# Altium schematic sheet RealTime.SchDoc(RealTime)
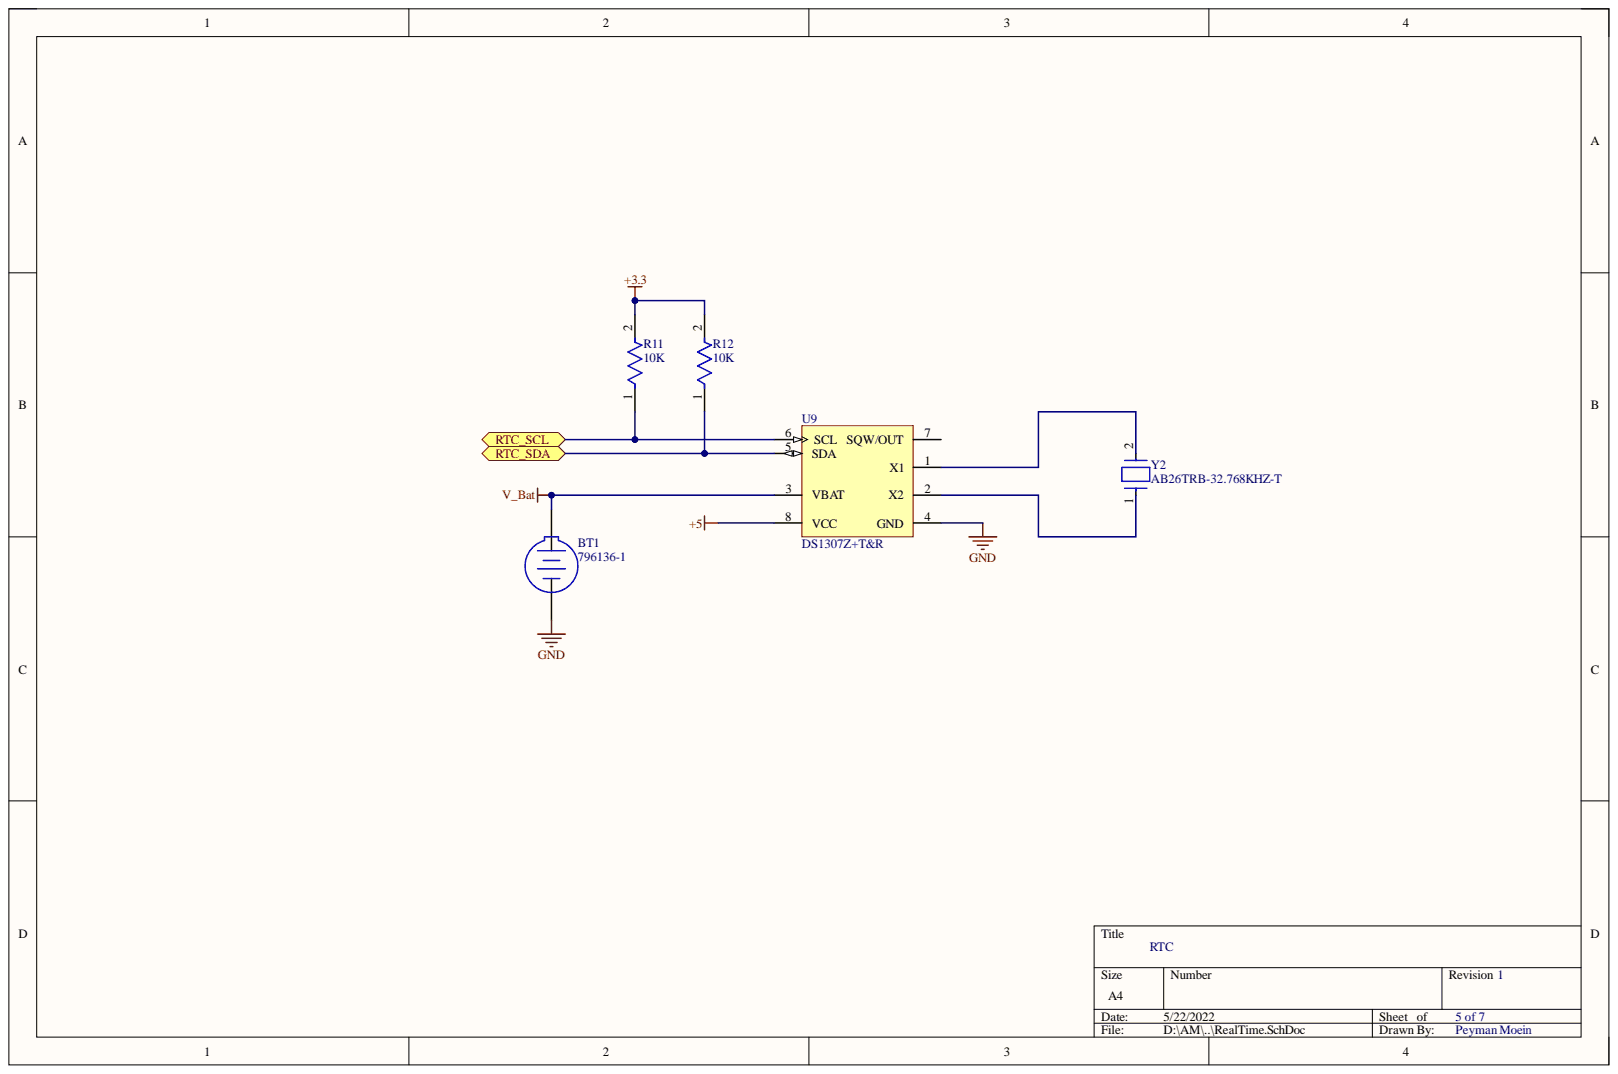
[diagram p0: RealTime.SchDoc]

## Parts
BT1  796136-1  [TECO-796136-1_V]
R11  10K  [FP-CRCW0805-e3-MFG]
R12  10K  [FP-CRCW0805-e3-MFG]
U9  DS1307Z+T&R  [S8+2_L]
Y2  AB26TRB-32.768KHZ-T  [FP-AB26TRB-MFG]

## Ports
RTC_SCL, RTC_SDA

## Nets
+3.3: R11.2 R12.2
+5: U9.8(VCC)
GND: BT1.2 U9.4(GND)
V_Bat: BT1.1 U9.3(VBAT)
RTC_SCL: R11.1 U9.6(SCL) ; port RTC_SCL
RTC_SDA: R12.1 U9.5(SDA) ; port RTC_SDA
NetU9_1: U9.1(X1) Y2.2
NetU9_2: U9.2(X2) Y2.1

Not connected: U9 7(SQW/OUT)

## Library data of the parts
BT1 = CMP-1684-00006-2: Battery Connector, 1 Cell, CR2032, 2-Pin SMD, RoHS, Tape and Reel; Case\Package: 0603; Forward Current: 20 mA; Forward Voltage: 2.8 V; Illumination Colour: Blue; Lens Style: Diffused; Luminous Intensity: 28 mcd; Nominal Vf: 3.3 V; Viewing Angle: 130 degrees; Case Code (Imperial): 0603; Case Code (Metric): 1608; Colour: Blue; Component Kind: Standard; ComponentLink1Description: Manufacturer URL; ComponentLink1URL: http://www.te.com/; ComponentLink2Description: Datasheet; ComponentLink2URL: http://www.te.com/commerce/DocumentDelivery/DDEController?Action=srchrtrv&DocNm=796136&DocType=Customer+Drawing&DocLang=English&DocFormat=pdf&PartCntxt=796136-1; DatasheetVersion: Dec-13; Height: 0.8 mm; Length: 1.6 mm; Library Name: Altium Content Vault; Max Operating Temperature: 80 degC; Min Operating Temperature: -20 degC; Mount: Surface Mount; Package Quantity: 3000; PackageDescription: 2-Pin Surface Mount Device, Body 32.31 x 19.05 mm; PackageReference: 796136-1; Packaging: Tape and Reel; Pins: 2; Radiation Hardening: No; RoHSCompliant: true; Width: 0.8 mm
R11 R12 = CMP-02407-004193-1: RES Thick Film, 2.94Ω, 1%, 0.125W, 100ppm/°C, 0805; Max Power Dissipation: 125mW; Resistance: 2.94R; Voltage Rating: 150V; Case Code (Imperial): 0805; Case Code (Metric): 2012; Case/Package: 0805; Component Kind: Standard; Composition: Thick Film; Contact Plating: Tin; Depth: 1.25mm; Features: Automotive AEC-Q200; Height: 0.02inch; Lead Free: Lead Free; Length: 2mm; Library Name: Altium Content Vault; Max Operating Temperature: 155°C; Military Standard: Not; Min Operating Temperature: -55°C; Number of Terminations: 2; Package Quantity: 10; Packaging: Tape and Reel; Power Rating: 125mW; Radiation Hardening: No; Temperature Coefficient: 100ppm/°C; Termination: SMD/SMT; Tolerance: 1%; Weight: 0.000194oz; Width: 0.049inch
U9 = CMP-0254-00305-1: 64 x 8, Serial, I2C Real-Time Clock, 5.0V, 8-Pin SO, 0 to 70 degC, Pb-Free, Reel; Code_IPC: SOIC127P600-8; Code_JEDEC: MS-012-AA; Component Kind: Standard; ComponentLink1Description: Manufacturer URL; ComponentLink1URL: http://www.maxim-ic.com; ComponentLink2Description: Datasheet; ComponentLink2URL: http://datasheets.maxim-ic.com/en/ds/DS1307.pdf; ComponentLink3Description: Package Specification; ComponentLink3URL: http://pdfserv.maxim-ic.com/package_dwgs/21-0041.PDF; DatasheetVersion: 10-Feb-2008; Library Name: Altium Content Vault; PackageDescription: 8-Pin Small-Outline IC, Narrow (0.15in), 1.27 mm Pitch, SOIC (N), Pb-Free; PackageReference: S8+2; PackageVersion: revC, 2010
Y2 = CMP-27762-006068-1: CRYSTAL 32.7680KHZ 12.5PF TH; ESR (Equivalent Series Resistance): 50kR; Frequency Stability: -0.034ppm; Frequency Tolerance: 20ppm; Case/Package: Radial; Component Kind: Standard; Depth: 1.9mm; Frequency: 32.768kHz; Height: 0.075inch; Lead Free: Lead Free; Lead Pitch: 2.54mm; Length: 6mm; Library Name: Altium Content Vault; Load Capacitance: 12.5pF; Max Operating Temperature: 85°C; Min Operating Temperature: -40°C; Mount: Surface Mount; Number of Pins: 2; Operating Frequency: 32.768 kHz; Package Quantity: 500; Packaging: Tape and Reel; REACH SVHC: No SVHC; Series: AB26TRB; Series Resistance: 50kR; Termination: Radial; Tolerance: 20ppm
